# Altium schematic sheet SC20100S-Micro.SchDoc(SC20100S-Micro)
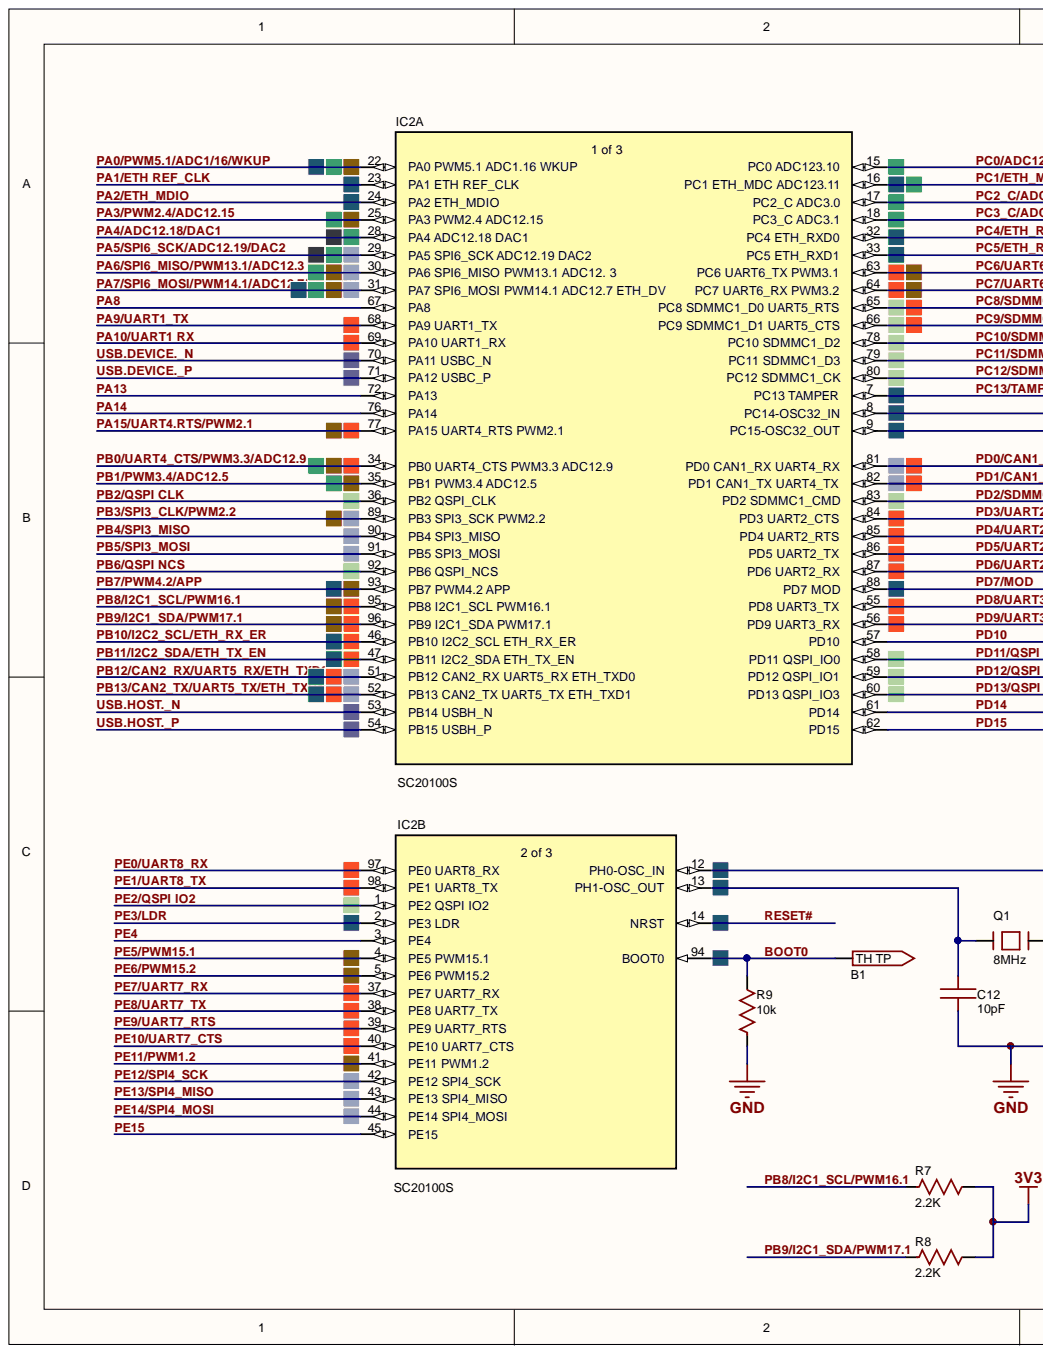
[diagram p0: SC20100S-Micro.SchDoc, left]
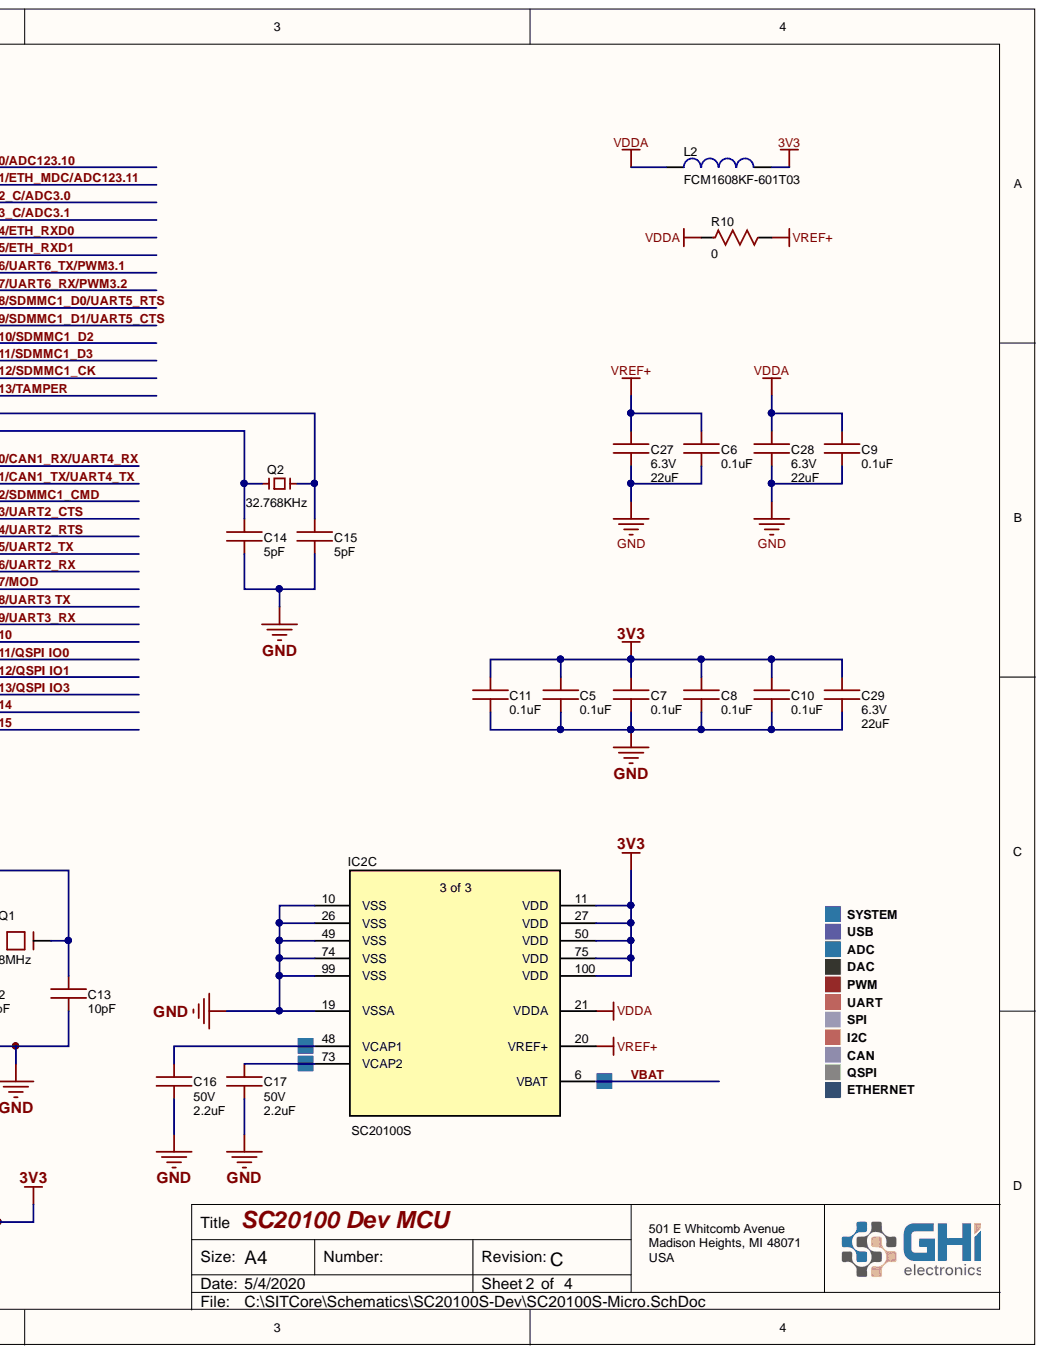
[diagram p1: SC20100S-Micro.SchDoc, right]

## Parts
B1  TH Testpad 32mil (32mil ar)  [32mil TH]  (value not drawn)  (no BOM)
C5  value 0.1uF  [C0603 (1608 Metric)]  (hidden comment: 0603 Cap 0.1uF)
C6  value 0.1uF  [C0603 (1608 Metric)]  (hidden comment: 0603 Cap 0.1uF)
C7  value 0.1uF  [C0603 (1608 Metric)]  (hidden comment: 0603 Cap 0.1uF)
C8  value 0.1uF  [C0603 (1608 Metric)]  (hidden comment: 0603 Cap 0.1uF)
C9  value 0.1uF  [C0603 (1608 Metric)]  (hidden comment: 0603 Cap 0.1uF)
C10  value 0.1uF  [C0603 (1608 Metric)]  (hidden comment: 0603 Cap 0.1uF)
C11  value 0.1uF  [C0603 (1608 Metric)]  (hidden comment: 0603 Cap 0.1uF)
C12  value 10pF  [C0603 (1608 Metric)]  (hidden comment: 0603 Cap 10pF)
C13  value 10pF  [C0603 (1608 Metric)]  (hidden comment: 0603 Cap 10pF)
C14  value 5pF  [C0603 (1608 Metric)]  (hidden comment: 0603 Cap 5pF)
C15  value 5pF  [C0603 (1608 Metric)]  (hidden comment: 0603 Cap 5pF)
C16  value 2.2uF  Voltage: 50V  [C0805]  (hidden comment: 0805 Cap 2.2uF)
C17  value 2.2uF  Voltage: 50V  [C0805]  (hidden comment: 0805 Cap 2.2uF)
C27  value 22uF  Voltage: 6.3V  [C0805]  (hidden comment: 0805 Cap 22uF)
C28  value 22uF  Voltage: 6.3V  [C0805]  (hidden comment: 0805 Cap 22uF)
C29  value 22uF  Voltage: 6.3V  [C0805]  (hidden comment: 0805 Cap 22uF)
IC2  SC20100S  [LQFP100]  (parts A,B,C)
IC2D  SC20100S  [LQFP100]
L2  value FCM1608KF-601T03  [L0603]  (hidden comment: BEAD)
Q1  value 8MHz  [CRYSTAL_3.2X2.5]  (hidden comment: CRYSTAL3.2X2.5)
Q2  value 32.768KHz  [Crystal 3.2mm x 1.5mm]  (hidden comment: 32.768KHz 7pF 20ppm)
R7  value 2.2K  [R0603 (1608 Metric)]  (hidden comment: 0603 2.2K)
R8  value 2.2K  [R0603 (1608 Metric)]  (hidden comment: 0603 2.2K)
R9  value 10k  [R0603 (1608 Metric)]  (hidden comment: 0603 10K)
R10  value 0  [R0603]  (hidden comment: 0603 Res 0)

## Nets
3V3: C5.2 C7.2 C8.2 C10.2 C11.2 C29.2 IC2.11(VDD) IC2.27(VDD) IC2.50(VDD) IC2.75(VDD) IC2.100(VDD) L2.2 R7.2 R8.2
GND: C5.1 C6.1 C7.1 C8.1 C9.1 C10.1 C11.1 C12.1 C13.1 C14.1 C15.1 C16.1 C17.1 C27.1 C28.1 C29.1 IC2.10(VSS) IC2.19(VSSA) IC2.26(VSS) IC2.49(VSS) IC2.74(VSS) IC2.99(VSS) R9.1
VDDA: C9.2 C28.2 IC2.21(VDDA) L2.1 R10.1
VREF+: C6.2 C27.2 IC2.20(VREF+) R10.2
BOOT0: B1.1 IC2.94(BOOT0) R9.2
PA0/PWM5.1/ADC1/16/WKUP: IC2.22(PA0_PWM5.1_ADC1.16_WKUP)
PA1/ETH REF_CLK: IC2.23(PA1_ETH_REF_CLK)
PA2/ETH_MDIO: IC2.24(PA2_ETH_MDIO)
PA3/PWM2.4/ADC12.15: IC2.25(PA3_PWM2.4_ADC12.15)
PA4/ADC12.18/DAC1: IC2.28(PA4_ADC12.18_DAC1)
PA5/SPI6_SCK/ADC12.19/DAC2: IC2.29(PA5_SPI6_SCK_ADC12.19_DAC2)
PA6/SPI6_MISO/PWM13.1/ADC12.3: IC2.30
PA7/SPI6_MOSI/PWM14.1/ADC12.7/ETH_DV: IC2.31
PA8: IC2.67(PA8)
PA9/UART1_TX: IC2.68(PA9_UART1_TX)
PA10/UART1 RX: IC2.69(PA10_UART1_RX)
PA13: IC2.72(PA13)
PA14: IC2.76(PA14)
PA15/UART4.RTS/PWM2.1: IC2.77(PA15_UART4_RTS_PWM2.1)
PB0/UART4_CTS/PWM3.3/ADC12.9: IC2.34(PB0_UART4_CTS_PWM3.3_ADC12.9)
PB1/PWM3.4/ADC12.5: IC2.35(PB1_PWM3.4_ADC12.5)
PB2/QSPI CLK: IC2.36(PB2_QSPI_CLK)
PB3/SPI3_CLK/PWM2.2: IC2.89(PB3_SPI3_SCK_PWM2.2)
PB4/SPI3_MISO: IC2.90(PB4_SPI3_MISO)
PB5/SPI3_MOSI: IC2.91(PB5_SPI3_MOSI)
PB6/QSPI NCS: IC2.92(PB6_QSPI_NCS)
PB7/PWM4.2/APP: IC2.93(PB7_PWM4.2_APP)
PB8/I2C1_SCL/PWM16.1: IC2.95(PB8_I2C1_SCL_PWM16.1) R7.1
PB9/I2C1_SDA/PWM17.1: IC2.96(PB9_I2C1_SDA_PWM17.1) R8.1
PB10/I2C2_SCL/ETH_RX_ER: IC2.46(PB10_I2C2_SCL_ETH_RX_ER)
PB11/I2C2_SDA/ETH_TX_EN: IC2.47(PB11_I2C2_SDA_ETH_TX_EN)
PB12/CAN2_RX/UART5_RX/ETH_TXD0: IC2.51(PB12_CAN2_RX_UART5_RX_ETH_TXD0)
PB13/CAN2_TX/UART5_TX/ETH_TXD1: IC2.52(PB13_CAN2_TX_UART5_TX_ETH_TXD1)
PC0/ADC123.10: IC2.15(PC0_ADC123.10)
PC1/ETH_MDC/ADC123.11: IC2.16(PC1_ETH_MDC_ADC123.11)
PC2_C/ADC3.0: IC2.17(PC2_C_ADC3.0)
PC3_C/ADC3.1: IC2.18(PC3_C_ADC3.1)
PC4/ETH_RXD0: IC2.32(PC4_ETH_RXD0)
PC5/ETH_RXD1: IC2.33(PC5_ETH_RXD1)
PC6/UART6_TX/PWM3.1: IC2.63(PC6_UART6_TX_PWM3.1)
PC7/UART6_RX/PWM3.2: IC2.64(PC7_UART6_RX_PWM3.2)
PC8/SDMMC1_D0/UART5_RTS: IC2.65(PC8_SDMMC1_D0_UART5_RTS)
PC9/SDMMC1_D1/UART5_CTS: IC2.66(PC9_SDMMC1_D1_UART5_CTS)
PC10/SDMMC1_D2: IC2.78(PC10_SDMMC1_D2)
PC11/SDMMC1_D3: IC2.79(PC11_SDMMC1_D3)
PC12/SDMMC1_CK: IC2.80(PC12_SDMMC1_CK)
PC13/TAMPER: IC2.7(PC13_TAMPER)
PD0/CAN1_RX/UART4_RX: IC2.81(PD0_CAN1_RX_UART4_RX)
PD1/CAN1_TX/UART4_TX: IC2.82(PD1_CAN1_TX_UART4_TX)
PD2/SDMMC1_CMD: IC2.83(PD2_SDMMC1_CMD)
PD3/UART2_CTS: IC2.84(PD3_UART2_CTS)
PD4/UART2_RTS: IC2.85(PD4_UART2_RTS)
PD5/UART2_TX: IC2.86(PD5_UART2_TX)
PD6/UART2_RX: IC2.87(PD6_UART2_RX)
PD7/MOD: IC2.88(PD7_MOD)
PD8/UART3 TX: IC2.55(PD8_UART3_TX)
PD9/UART3_RX: IC2.56(PD9_UART3_RX)
PD10: IC2.57(PD10)
PD11/QSPI IO0: IC2.58(PD11_QSPI_IO0)
PD12/QSPI IO1: IC2.59(PD12_QSPI_IO1)
PD13/QSPI IO3: IC2.60(PD13_QSPI_IO3)
PD14: IC2.61(PD14)
PD15: IC2.62(PD15)
PE0/UART8_RX: IC2.97(PE0_UART8_RX)
PE1/UART8_TX: IC2.98(PE1_UART8_TX)
PE2/QSPI IO2: IC2.1(PE2_QSPI_IO2)
PE3/LDR: IC2.2(PE3_LDR)
PE4: IC2.3(PE4)
PE5/PWM15.1: IC2.4(PE5_PWM15.1)
PE6/PWM15.2: IC2.5(PE6_PWM15.2)
PE7/UART7_RX: IC2.37(PE7_UART7_RX)
PE8/UART7_TX: IC2.38(PE8_UART7_TX)
PE9/UART7_RTS: IC2.39(PE9_UART7_RTS)
PE10/UART7_CTS: IC2.40(PE10_UART7_CTS)
PE11/PWM1.2: IC2.41(PE11_PWM1.2)
PE12/SPI4_SCK: IC2.42(PE12_SPI4_SCK)
PE13/SPI4_MISO: IC2.43(PE13_SPI4_MISO)
PE14/SPI4_MOSI: IC2.44(PE14_SPI4_MOSI)
PE15: IC2.45(PE15)
RESET#: IC2.14(NRST)
USB.DEVICE._N: IC2.70(PA11_USBC_N)
USB.DEVICE._P: IC2.71(PA12_USBC_P)
USB.HOST._N: IC2.53(PB14_USBH_N)
USB.HOST._P: IC2.54(PB15_USBH_P)
VBAT: IC2.6(VBAT)
NetC12_2: C12.2 IC2.13(PH1-OSC_OUT) Q1.1
NetC13_2: C13.2 IC2.12(PH0-OSC_IN) Q1.3
NetC14_2: C14.2 IC2.9(PC15-OSC32_OUT) Q2.1
NetC15_2: C15.2 IC2.8(PC14-OSC32_IN) Q2.2
NetC16_2: C16.2 IC2.48(VCAP1)
NetC17_2: C17.2 IC2.73(VCAP2)

## Library data of the parts
B1 = TH Testpad 32mil (32mil ar): TH Testpad 32mil (32mil annular ring); Library Name: GHI.SchLib
C5-C11 = 0603 Cap 0.1uF: 0.1uF ±5% 50V X7R 0603 Multilayer Ceramic Capacitors MLCC - SMD/SMT RoHS; Mfr.Part #: CL10B104JB8NNNC; Voltage: 50V; Tolerance: ±5%; Package: 0603; LCSC Part #: C24452; Digikey: 1276-1033-1-ND; Component Kind: Standard; Category: Ceramic; Capacitance: 0.1uF; Library Name: GHI-Passives.SchLib
C12 C13 = 0603 Cap 10pF: 10pF ±0.5pF 50V C0G 0402 Multilayer Ceramic Capacitors MLCC - SMD/SMT RoHS; Mfr.Part #: CL10C100DB8NNNC; Manufacturer: Samsung Electro-Mechanics; Voltage: 50V; Tolerance: ±0.5pF; Package: 0603; LCSC Part #: C170139; Component Kind: Standard; Category: Ceramic; Capacitance: 10pF; Library Name: GHI-Passives.SchLib
C14 C15 = 0603 Cap 5pF: 10pF ±0.5pF 50V C0G 0402 Multilayer Ceramic Capacitors MLCC - SMD/SMT RoHS; Mfr.Part #: CL10C050CB8NNNC; Manufacturer: Samsung Electro-Mechanics; Tolerance: ±0.25pF; Voltage: 50V; Package: 0603; LCSC Part #: C93045; Component Kind: Standard; Category: Ceramic; Capacitance: 5pF; Library Name: GHI-Passives.SchLib
C16 C17 = 0805 Cap 2.2uF: 2.2uF ±10% 50V X5R 0805 Multilayer Ceramic Capacitors MLCC - SMD/SMT RoHS; Mfr.Part #: CL21A225KBQNNNE; Tolerance: ±10%; Package: 0805; LCSC Part #: C377773; Component Kind: Standard; Category: Ceramic; Capacitance: 2.2uF; Library Name: GHI-Passives.SchLib
C27-C29 = 0805 Cap 22uF: 22uF ±20% 6.3V X5R 0805 Multilayer Ceramic Capacitors MLCC - SMD/SMT RoHS; Mfr.Part #: CL21A226MQCLRNC; Tolerance: ±20%; Package: 0805; LCSC Part #: C307530; Component Kind: Standard; Category: Ceramic; Capacitance: 22uF; Library Name: GHI-Passives.SchLib
IC2 IC2D = SC20100S: No Description Available; Manufacturer_Part_Number: stm32h7x3vit; Vendor: STMicroelectronics; Value: Value; Type: DEV; RefDes: RefDes; Component Kind: Standard; Library Name: GHI-Integrated Circuits.SchLib; Copyright: Copyright (C) 2016 Accelerated Designs. All rights reserved
L2 = (no symbol name): 600Ω @ 100MHz 350mA 1 500mΩ 0603 Ferrite Beads RoHS; Revision: TAITEC; Package: 0603; Mfr.Part #: FCM1608KF-601T03; LCSC Part #: C141723; Component Kind: Standard; Library Name: GHI.SchLib
Q1 = CRYSTAL3.2X2.5: 4 pad 3.2 mm x 2.5 mm Crystal; SymbolName_1: CRYSTAL.2PAD; GateName_1: G$1; LibraryName: GHI2; DeviceSetName: CRYSTAL3.2X2.5; Component Kind: Standard; Library Name: GHI.SchLib
Q2 = 32.768KHz 7pF 20ppm 2 Pad 3.2mm x 1.5mm: Crystal 2 Pad 3.2mm x 1.5mm 32.768KHz; Manufacturer Part Number: 6K30032768K0702070401; GHI Part Number: EPC-Q32KHZ; Shunt Capacitance: 1.3pF Typ; Mode: AT/Fundamental; Load Capacitance: 10 pF; Frequency Tolerance: +- 20ppm; Equivalent Series Resistance: 70k Ohm Max; Driver Level (Typical): 1uW Max; Aging @25 C 1st Year (Max): +- 3ppm/year; Storage Temperature: -55C to +125 C; Shock Resistance: N/A; Operating Temperature Range: -40 C to +85 C; Library Name: GHI.SchLib; Frequency Stability: N/A; Frequency: 32.768KHz; Component Kind: Standard
R7 R8 = 0603 Res 2.2K: 2.2K ±1% 1/10W ±100ppm/℃ 0603 Chip Resistor - Surface Mount RoHS; Mfr.Part #: RC0603FR-072K2L; Manufacturer: YAGEO; Voltage Rating: *V; Resistance Tolerance: ±1%; Resistance: 2.2K; Power Rating: 1/10W; Package: 0603; LCSC Part #: C114662; Component Kind: Standard; Library Name: GHI-Passives.SchLib
R9 = 0603 Res 10K: 10K ±5% 1/10W ±100ppm/℃ 0603 Chip Resistor - Surface Mount RoHS; Mfr.Part #: RC0603JR-0710KL; Manufacturer: YAGEO; Voltage Rating: *V; Resistance Tolerance: ±5%; Resistance: 10k; Power Rating: 1/10W; Package: 0603; LCSC Part #: C99198; Component Kind: Standard; Library Name: GHI-Passives.SchLib
R10 = 0603 Res 0: ±5% 1/10W 0603 Chip Resistor - Surface Mount RoHS; Mfr.Part #: RC0603JR-070RL; Manufacturer: YAGEO; Voltage Rating: *V; Resistance Tolerance: ±5%; Resistance: 0; Power Rating: 1/10W; Package: 0603; LCSC Part #: C95177; Component Kind: Standard; Library Name: GHI-Passives.SchLib
